# Altium schematic sheet SIM808.SchDoc
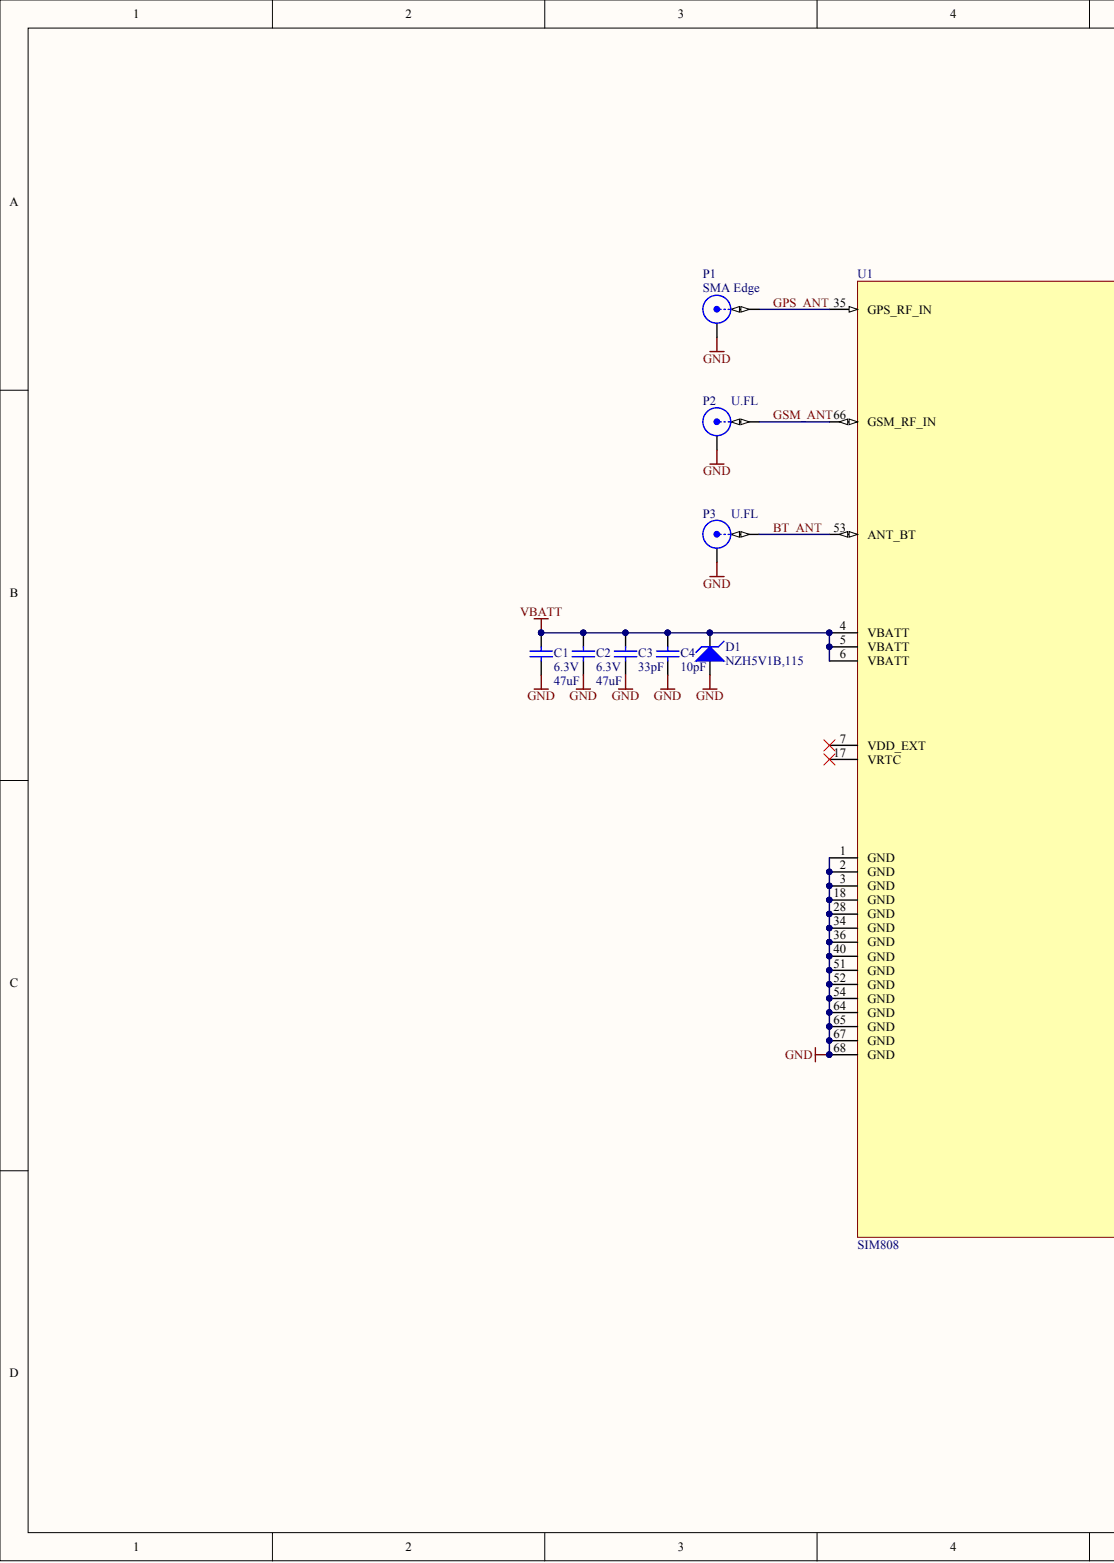
[diagram p0: SIM808.SchDoc, left]
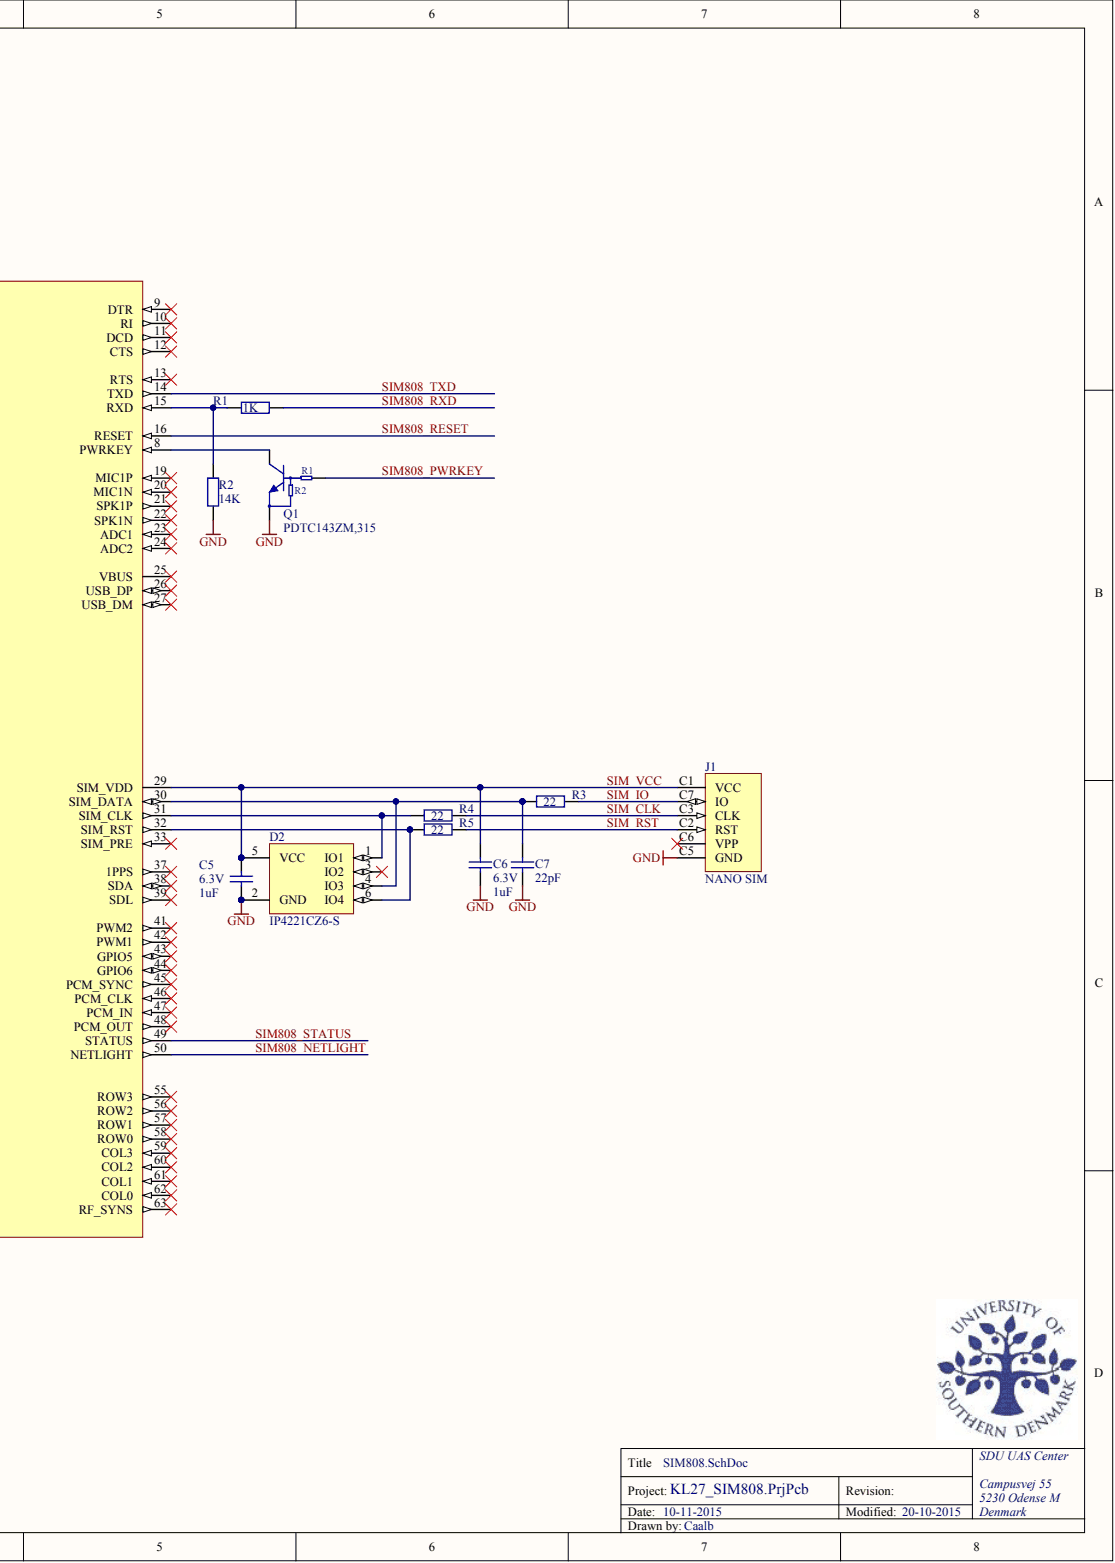
[diagram p1: SIM808.SchDoc, right]

## Parts
C1  6.3V  value 47uF  [0805C]
C2  6.3V  value 47uF  [0805C]
C3  value 33pF  [0402C]  (hidden comment: XXV)
C4  value 10pF  [0402C]  (hidden comment: XXV)
C5  6.3V  value 1uF  [0402C]
C6  6.3V  value 1uF  [0402C]
C7  value 22pF  [0402C]  (hidden comment: XXV)
D1  NZH5V1B,115  [SOD-123F]
D2  IP4221CZ6-S  [SOT886]
J1  NANO SIM  [693043020611]
P1  SMA Edge  [SMA-J-P-H-ST-EM1]
P2  U.FL  [U.FL_Coaxial]
P3  U.FL  [U.FL_Coaxial]
Q1  PDTC143ZM,315  [SOT883]
R1  1%  value 1K  [0402R]
R2  1%  value 14K  [0402R]
R3  value 22  [0402R]  (hidden comment: 1%)
R4  value 22  [0402R]  (hidden comment: 1%)
R5  value 22  [0402R]  (hidden comment: 1%)
U1  SIM808  [SIM808]

## Nets
GND: C1.1 C2.1 C3.2 C4.1 C5.1 C6.1 C7.1 D1.2 D2.2(GND) J1.C5(GND) P1.2 P2.2 P3.2 Q1.2 R2.1 U1.1(GND) U1.2(GND) U1.3(GND) U1.18(GND) U1.28(GND) U1.34(GND) U1.36(GND) U1.40(GND) U1.51(GND) U1.52(GND) U1.54(GND) U1.64(GND) U1.65(GND) U1.67(GND) U1.68(GND)
VBATT: C1.2 C2.2 C3.1 C4.2 D1.1 U1.4(VBATT) U1.5(VBATT) U1.6(VBATT)
BT_ANT: P3.1 U1.53(ANT_BT)
GPS_ANT: P1.1 U1.35(GPS_RF_IN)
GSM_ANT: P2.1 U1.66(GSM_RF_IN)
SIM808_NETLIGHT: U1.50(NETLIGHT)
SIM808_PWRKEY: Q1.1
SIM808_RESET: U1.16(RESET)
SIM808_RXD: R1.1
SIM808_STATUS: U1.49(STATUS)
SIM808_TXD: U1.14(TXD)
SIM_CLK: J1.C3(CLK) R4.1
SIM_IO: J1.C7(IO) R3.1
SIM_RST: J1.C2(RST) R5.1
SIM_VCC: C5.2 C6.2 D2.5(VCC) J1.C1(VCC) U1.29(SIM_VDD)
NetC7_2: C7.2 D2.4(IO3) R3.2 U1.30(SIM_DATA)
NetD2_1: D2.1(IO1) R4.2 U1.31(SIM_CLK)
NetD2_6: D2.6(IO4) R5.2 U1.32(SIM_RST)
NetQ1_3: Q1.3 U1.8(PWRKEY)
NetR1_2: R1.2 R2.2 U1.15(RXD)

Not connected: D2 3(IO2); J1 C6(VPP); U1 7(VDD_EXT) 9(DTR) 10(RI) 11(DCD) 12(CTS) 13(RTS) 17(VRTC) 19(MIC1P) 20(MIC1N) 21(SPK1P) 22(SPK1N) 23(ADC1) 24(ADC2) 25(VBUS) 26(USB_DP) 27(USB_DM) 33(SIM_PRE) 37(1PPS) 38(SDA) 39(SDL) 41(PWM2) 42(PWM1) 43(GPIO5) 44(GPIO6) 45(PCM_SYNC) 46(PCM_CLK) 47(PCM_IN) 48(PCM_OUT) 55(ROW3) 56(ROW2) 57(ROW1) 58(ROW0) 59(COL3) 60(COL2) 61(COL1) 62(COL0) 63(RF_SYNS)

## Library data of the parts
C1 C2 = Cap_0805_47uF: Capacitor, SMD, 0805, X5R; Component Kind: Standard; ComponentLink1Description: http://www.tdk.com/pdf/general_B11.pdf; ComponentLink1URL: http://www.tdk.com/pdf/general_B11.pdf; Signal Integrity: Cap; Simulation: CAP; Supplier 1: RS-Components; Supplier 2: Farnell; Supplier 3: Digi-Key; Supplier 4: Mouser; Supplier Part Number 1: 810-C2012X5R0J476M; Supplier Part Number 3: 445-5987-1-ND; Supplier Part Number 4: 810-C2012X5R0J476M; Library Name: Ofte_Benyttede.SCHLIB
C3 C4 C7 = Cap_0402: Capacitor, SMD, 0402; Component Kind: Standard; Signal Integrity: Cap; Simulation: CAP; Supplier 1: RS-Components; Supplier 2: Farnell; Supplier 3: Digi-Key; Supplier 4: Mouser; Library Name: Ofte_Benyttede.SCHLIB
C5 C6 = Cap_0402_1uF: Capacitor, SMD, 0402, X5R; Component Kind: Standard; Signal Integrity: Cap; Simulation: CAP; Supplier 1: RS-Components; Supplier 2: Farnell; Supplier 3: Digi-Key; Supplier 4: Mouser; Library Name: Ofte_Benyttede.SCHLIB
D1 = NZH5V1B,115: DIODE ZENER 5.1V 500MW SOD123F; Component Kind: Standard; ComponentLink1Description: http://www.farnell.com/datasheets/1508365.pdf; ComponentLink1URL: http://www.farnell.com/datasheets/1508365.pdf; Supplier 1: RS-Components; Supplier 2: Farnell; Supplier 3: Digi-Key; Supplier 4: Mouser; Supplier Part Number 2: 2069484; Supplier Part Number 3: 568-6370-1-ND; Library Name: Philips_egne.SchLib
D2 = IP4221CZ6-S: ESD protection for high-speed interfaces; Component Kind: Standard; ComponentLink1Description: http://www.nxp.com/documents/data_sheet/IP4221CZ6-S.pdf; ComponentLink1URL: http://www.nxp.com/documents/data_sheet/IP4221CZ6-S.pdf; Supplier 1: RS-Components; Supplier 2: Farnell; Supplier 3: Digi-Key; Supplier 4: Mouser; Supplier Part Number 1: 725-8789; Supplier Part Number 2: 1829274; Supplier Part Number 3: 568-6340-6-ND; Library Name: Philips_egne.SchLib
J1 = 693043020611: ConCARD Nano SIM Card Connector Push& Pull - 6 Pins; Component Kind: Standard; ComponentLink1Description: http://www.farnell.com/cad/1889811.pdf; ComponentLink1URL: http://www.farnell.com/cad/1889811.pdf; Supplier 1: RS-Components; Supplier 2: Farnell; Supplier 3: Digi-Key; Supplier 4: Mouser; Supplier Part Number 2: 2470827; Supplier Part Number 3: 732-5954-1-ND; Supplier Part Number 4: 710-693043020611; Library Name: Connector_Div_egne.SchLib
P1 = SMA-J-P-H-ST-EM1: SMA 50 Ohm Edge Mount; Component Kind: Standard; Supplier 1: RS-Components; Supplier 2: Farnell; Supplier 3: Digi-Key; Supplier 4: Mouser; Library Name: Connector_Div_egne.SchLib
P2 P3 = U.FL_Coaxial: Ultra Small Surface Mount Coaxial Connectors; Component Kind: Standard; ComponentLink1Description: http://www.farnell.com/datasheets/91524.pdf; ComponentLink1URL: http://www.farnell.com/datasheets/91524.pdf; Signal Integrity: Connector; Supplier 1: RS-Components; Supplier 2: Farnell; Supplier 3: Digi-Key; Supplier 4: Mouser; Supplier Part Number 1: 557-981; Supplier Part Number 2: 1688077; Library Name: Ofte_Benyttede.SCHLIB
Q1 = PDTC143ZM,315: NPN 100 mA, 50 V resistor-equipped transistor 4.7K 47K; Component Kind: Standard; Supplier 1: RS-Components; Supplier 2: Farnell; Supplier 3: Digi-Key; Supplier 4: Mouser; Supplier Part Number 3: 568-2167-6-ND; Library Name: Philips_egne.SchLib
R1-R5 = Res_0402: Resistor, SMD, 0402, 0.063W; Component Kind: Standard; Signal Integrity: Res; Simulation: RESISTOR; Supplier 1: RS-Components; Supplier 2: Farnell; Supplier 3: Digi-Key; Supplier 4: Mouser; Library Name: Ofte_Benyttede.SCHLIB
U1 = SIM808: SIM808 GPS/GMS module; Component Kind: Standard; Supplier 1: RS-Components; Supplier 2: Farnell; Supplier 3: Digi-Key; Supplier 4: Mouser; Library Name: DivLib.SCHLIB
